# Altium schematic sheet [2]-Input.SchDoc
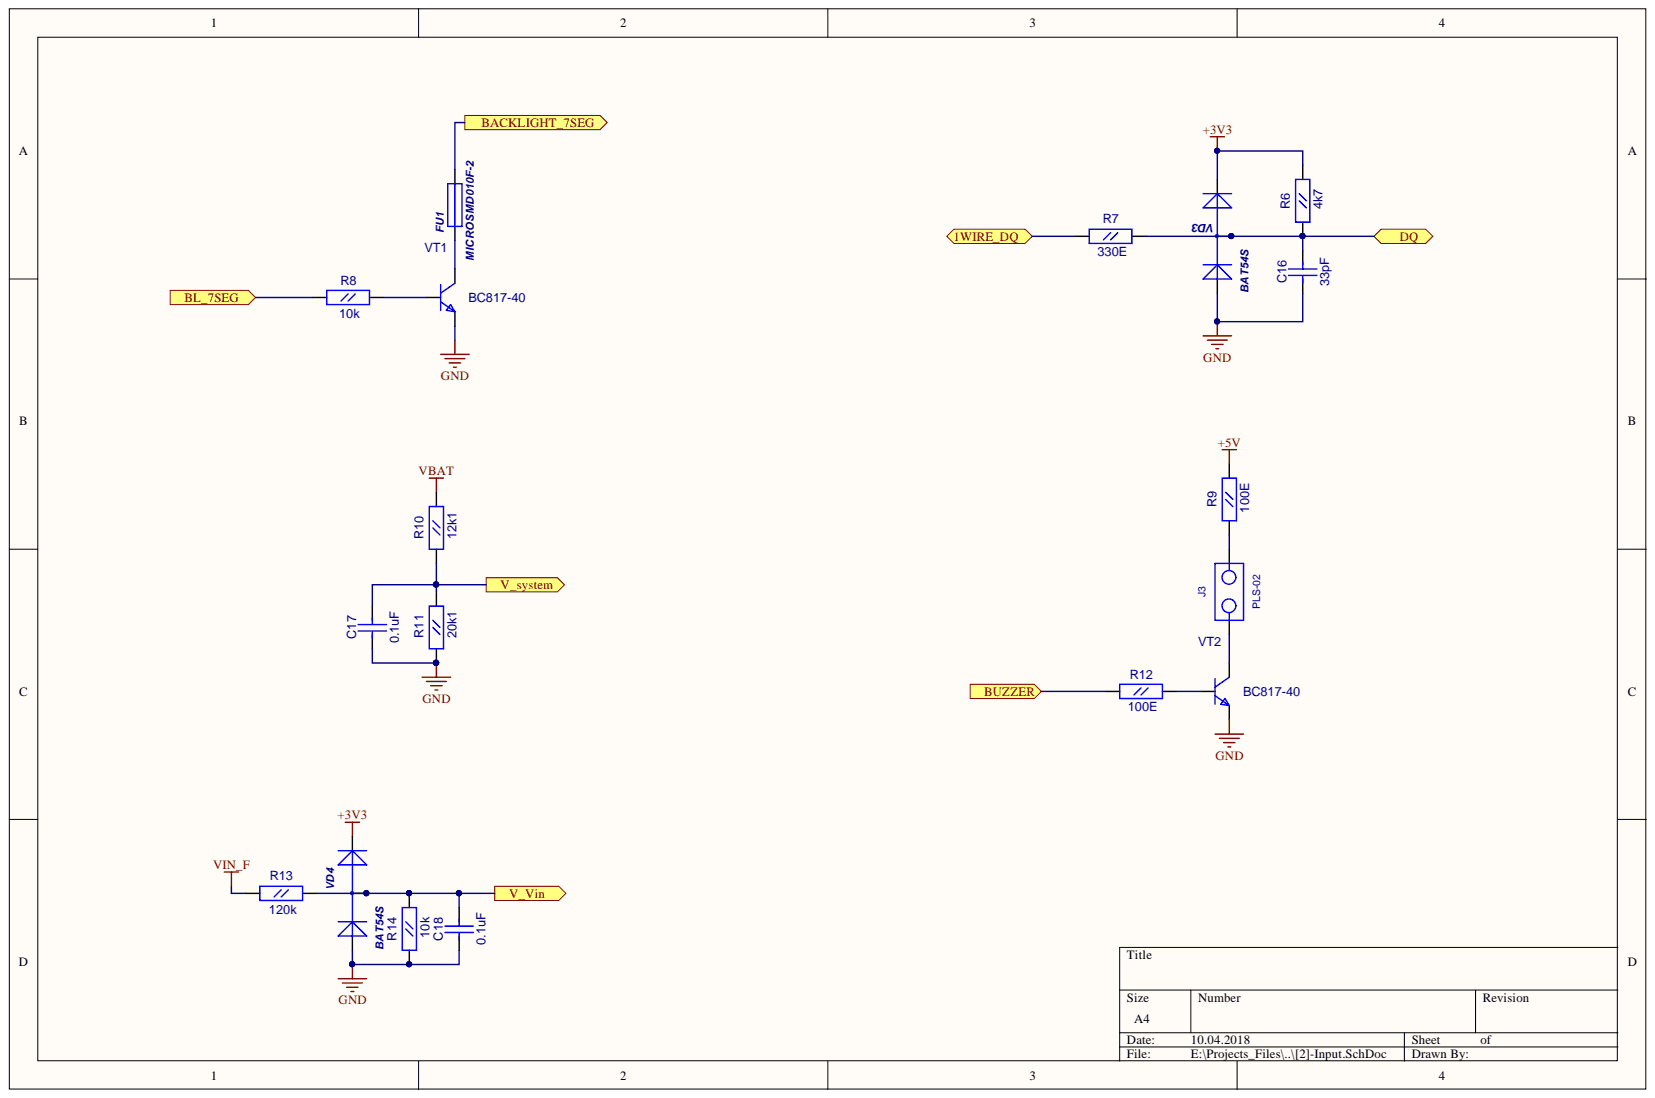
[diagram p0: [2]-Input.SchDoc]

## Parts
C16  33pF  [CC0603]
C17  0.1uF  [CC0603]
C18  0.1uF  [CC0603]
FU1  MICROSMD010F-2  [RC1210]
J3  PLS-02  [PLS-02]
R6  4k7  [RC0603]
R7  330E  [RC0603]
R8  10k  [RC0603]
R9  100E  [RC0603]
R10  12k1  [RC0603]
R11  20k1  [RC0603]
R12  100E  [RC0603]
R13  120k  [RC0603]
R14  10k  [RC0603]
VD3  BAT54S  [SOT-23(GSD)]
VD4  BAT54S  [SOT-23(GSD)]
VT1  BC817-40  [SOT-23(123)]
VT2  BC817-40  [SOT-23(123)]

## Ports
1WIRE_DQ, BACKLIGHT_7SEG, BL_7SEG, BUZZER, DQ, V_system, V_Vin

## Nets
+3V3: R6.2 VD3.2 VD4.2
+5V: R9.2
GND: C16.1 C17.1 C18.1 R11.1 R14.1 VD3.1 VD4.1 VT1.2 VT2.2
VBAT: R10.2
VIN_F: R13.1
1WIRE_DQ: R7.1 ; port 1WIRE_DQ
BACKLIGHT_7SEG: FU1.2 ; port BACKLIGHT_7SEG
BL_7SEG: R8.1 ; port BL_7SEG
BUZZER: R12.1 ; port BUZZER
DQ: C16.2 R6.1 R7.2 VD3.3 ; port DQ
V_system: C17.2 R10.1 R11.2 ; port V_system
V_Vin: C18.2 R13.2 R14.2 VD4.3 ; port V_Vin
NetFU1_1: FU1.1 VT1.3
NetJ3_1: J3.1 VT2.3
NetJ3_2: J3.2 R9.1
NetR8_2: R8.2 VT1.1
NetR12_2: R12.2 VT2.1

## Library data of the parts
C16-C18 = CC0603: Component Kind: Standard; Description: CC0603; Library Name: gprs_modem_2.SchLib
  C16: Value: 33pF
  C17 C18: Value: 0.1uF
FU1 = MICROSMD: Component Kind: Standard; Description: MICROSMD; Value: MICROSMD010F-2; Library Name: gprs_modem_2.SchLib
R6-R14 = RC0603: Component Kind: Standard; Description: RC0603; Library Name: gprs_modem_2.SchLib
  R6: Value: 4k7
  R7: Value: 330E
  R8 R14: Value: 10k
  R9 R12: Value: 100E
  R10: Value: 12k1
  R11: Value: 20k1
  R13: Value: 120k
VD3 VD4 = BAT54S: 1.0 Ampere General Purpose Rectifier; ComponentField6: Published 8-Jun-2000, Modified 11-July-2000: SIM Model Added.; Component Kind: Standard; Simulation: DIODE; Library Name: gprs_modem_2.SchLib
VT1 VT2 = BC846BLT1: NPN 500ma transistor; ComponentField7: Published 29-Sep-2000; Component Kind: Standard; OriginalName: BC846BLT1; Simulation: BC846BLT1; Value: BC817-40; Library Name: gprs_modem_2.SchLib
J3 = PLS-02: Component Kind: Standard; Description: PLS-02; Library Name: gprs_modem_2.SchLib
